# Altium schematic sheet 03 - Power.SchDoc
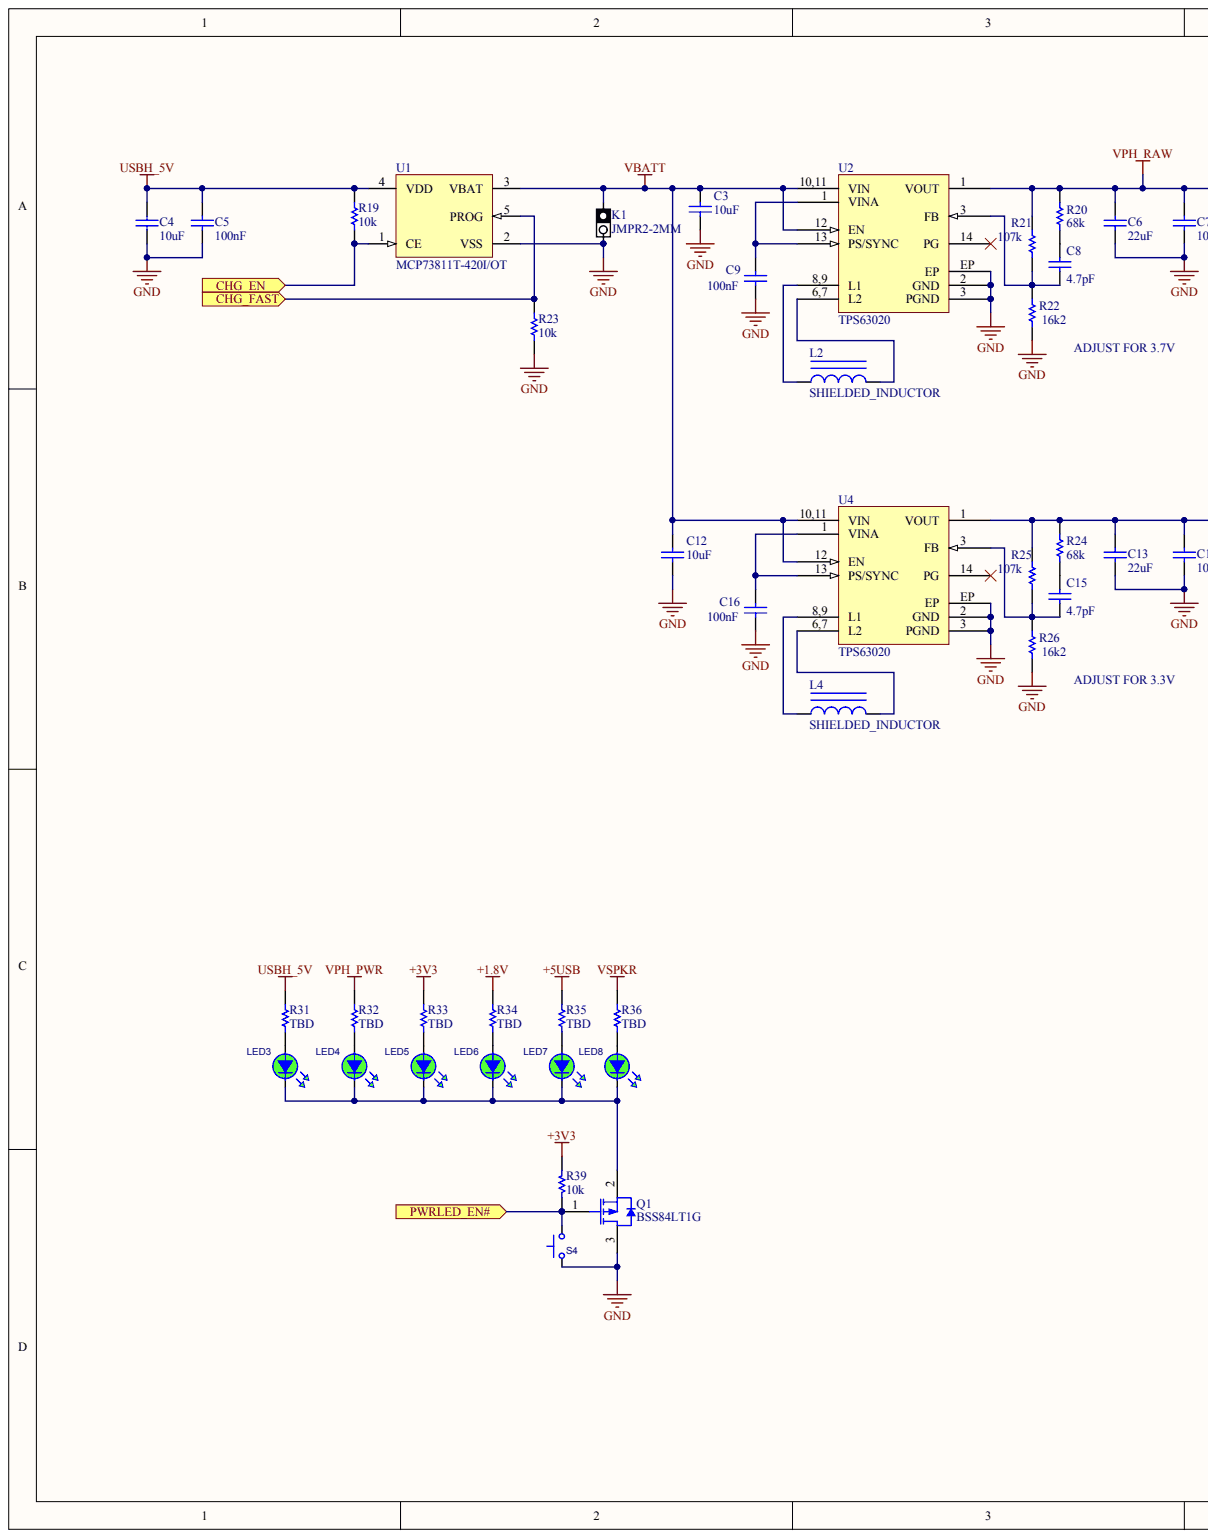
[diagram p0: 03 - Power.SchDoc, left]
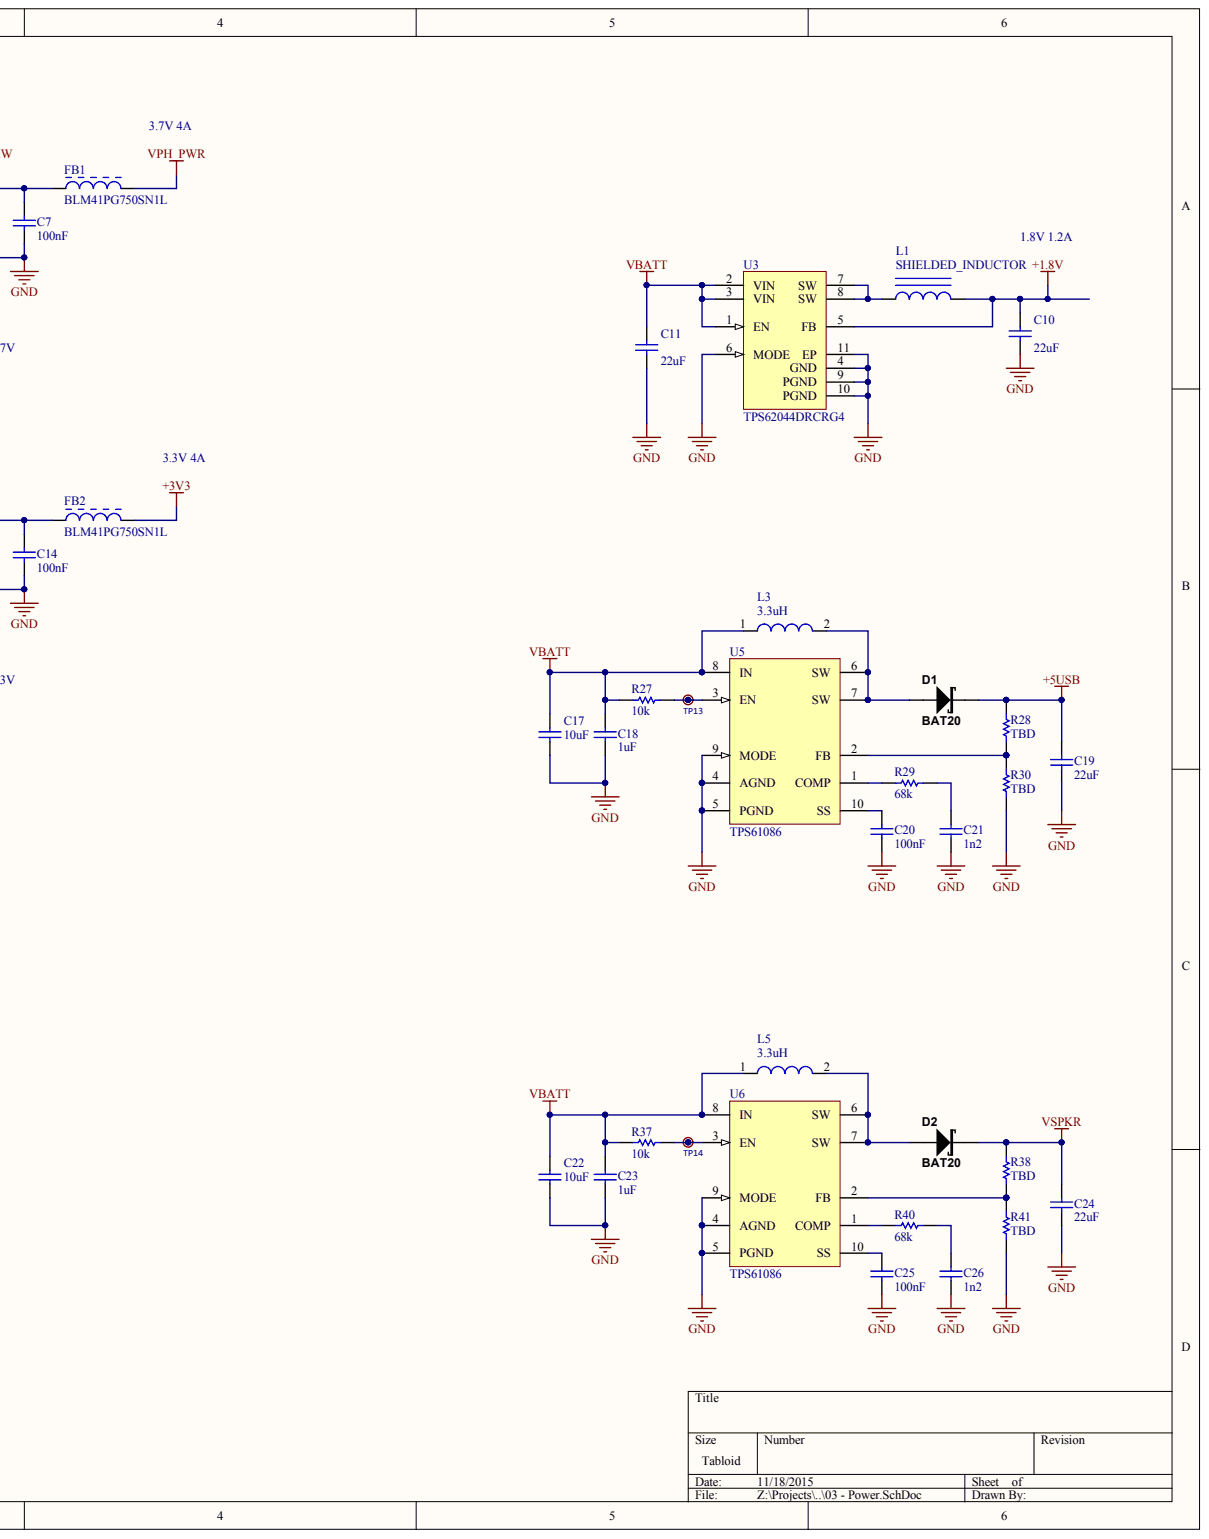
[diagram p1: 03 - Power.SchDoc, right]

## Parts
C3  value 10uF  [CAPC0805(2012)100_N]  (hidden comment: CAP 10uF 6.3V 0805(2012))
C4  value 10uF  [CAPC0805(2012)100_L]  (hidden comment: CAP 10uF 6.3V 0805(2012))
C5  value 100nF  [CAPC0805(2012)100_N]  (hidden comment: CAP 100nF 6.3V 0805(2012))
C6  value 22uF  [CAPC0805(2012)145_L]  (hidden comment: CAP 22uF 16V 0805(2012))
C7  value 100nF  [CAPC0805(2012)100_N]  (hidden comment: CAP 100nF 25V 0805(2012))
C8  value 4.7pF  [CAPC0805(2012)100_L]  (hidden comment: CAP 4.7pF 10V 0805(2012))
C9  value 100nF  [CAPC0805(2012)100_N]  (hidden comment: CAP 100nF 6.3V 0805(2012))
C10  value 22uF  [CAPC0805(2012)100_M]  (hidden comment: CAP 22uF 4V 0805(2012))
C11  value 22uF  [CAPC0805(2012)100_M]  (hidden comment: CAP 22uF 4V 0805(2012))
C12  value 10uF  [CAPC0805(2012)100_N]  (hidden comment: CAP 10uF 6.3V 0805(2012))
C13  value 22uF  [CAPC0805(2012)145_L]  (hidden comment: CAP 22uF 16V 0805(2012))
C14  value 100nF  [CAPC0805(2012)100_N]  (hidden comment: CAP 100nF 25V 0805(2012))
C15  value 4.7pF  [CAPC0805(2012)100_L]  (hidden comment: CAP 4.7pF 10V 0805(2012))
C16  value 100nF  [CAPC0805(2012)100_N]  (hidden comment: CAP 100nF 6.3V 0805(2012))
C17  value 10uF  [CAPC0805(2012)100_L]  (hidden comment: CAP 10uF 6.3V 0805(2012))
C18  value 1uF  [CAPC0805(2012)100_L]
C19  value 22uF  [CAPC0805(2012)145_L]  (hidden comment: CAP 22uF 16V 0805(2012))
C20  value 100nF  [CAPC0805(2012)100_N]  (hidden comment: CAP 100nF 6.3V 0805(2012))
C21  value 1n2  [CAPC0805(2012)100_N]
C22  value 10uF  [CAPC0805(2012)100_L]  (hidden comment: CAP 10uF 6.3V 0805(2012))
C23  value 1uF  [CAPC0805(2012)100_L]
C24  value 22uF  [CAPC0805(2012)145_L]  (hidden comment: CAP 22uF 16V 0805(2012))
C25  value 100nF  [CAPC0805(2012)100_N]  (hidden comment: CAP 100nF 6.3V 0805(2012))
C26  value 1n2  [CAPC0805(2012)100_N]
D1  BAT20  [SOD323]
D2  BAT20  [SOD323]
FB1  BLM41PG750SN1L  [MURA-BLM41-B35-CHIP-2_V2]
FB2  BLM41PG750SN1L  [MURA-BLM41-B35-CHIP-2_V2]
K1  JMPR2-2MM  [JMPR2-2MM]
L1  SHIELDED_INDUCTOR
L2  SHIELDED_INDUCTOR
L3  3.3uH  [SMD 0805_A]
L4  SHIELDED_INDUCTOR
L5  3.3uH  [SMD 0805_A]
LED3  VLMG1500-GS08  [LEDC0402(1005)_N-GRN]  (value not drawn)
LED4  VLMG1500-GS08  [LEDC0402(1005)_N-GRN]  (value not drawn)
LED5  VLMG1500-GS08  [LEDC0402(1005)_N-GRN]  (value not drawn)
LED6  VLMG1500-GS08  [LEDC0402(1005)_N-GRN]  (value not drawn)
LED7  VLMG1500-GS08  [LEDC0402(1005)_N-GRN]  (value not drawn)
LED8  VLMG1500-GS08  [LEDC0402(1005)_N-GRN]  (value not drawn)
Q1  BSS84LT1G  [ONSC-SOT-23-3-318-08_V]
R19  value 10k  [RESC0805(2012)_M]  (hidden comment: 10K 1% 0805(2012))
R20  value 68k  [RESC0805(2012)_L]  (hidden comment: 68K 5% 0805(2012))
R21  value 107k  [RESC0805(2012)_M]  (hidden comment: 107K 1% 0805(2012))
R22  value 16k2  [RESC0805(2012)_L]  (hidden comment: 16K2 1% 0805(2012))
R23  value 10k  [RESC0805(2012)_M]  (hidden comment: 10K 1% 0805(2012))
R24  value 68k  [RESC0805(2012)_L]  (hidden comment: 68K 5% 0805(2012))
R25  value 107k  [RESC0805(2012)_M]  (hidden comment: 107K 1% 0805(2012))
R26  value 16k2  [RESC0805(2012)_L]  (hidden comment: 16K2 1% 0805(2012))
R27  value 10k  [RESC0805(2012)_N]  (hidden comment: 20K 1% 0805(2012))
R28  value TBD  [RESC0805(2012)_N]  (hidden comment: 20K 1% 0805(2012))
R29  68k  [RESC0805(2012)_N]
R30  value TBD  [RESC0805(2012)_N]  (hidden comment: 20K 1% 0805(2012))
R31  value TBD  [RESC0805(2012)_M]
R32  value TBD  [RESC0805(2012)_M]
R33  value TBD  [RESC0805(2012)_M]
R34  value TBD  [RESC0805(2012)_M]
R35  value TBD  [RESC0805(2012)_M]
R36  value TBD  [RESC0805(2012)_M]
R37  value 10k  [RESC0805(2012)_N]  (hidden comment: 20K 1% 0805(2012))
R38  value TBD  [RESC0805(2012)_N]  (hidden comment: 20K 1% 0805(2012))
R39  value 10k  [RESC0805(2012)_M]  (hidden comment: 10K 1% 0805(2012))
R40  68k  [RESC0805(2012)_N]
R41  value TBD  [RESC0805(2012)_N]  (hidden comment: 20K 1% 0805(2012))
S4  KMR211GLFS  [KMR2]  (value not drawn)
TP13  (no value)  [TESTPOINT_035HOLE]
TP14  (no value)  [TESTPOINT_035HOLE]
U1  MCP73811T-420I/OT  [SOT-23-OT5_M]
U2  TPS63020
U3  TPS62044DRCRG4  [DRC10-2400X1650TP]
U4  TPS63020
U5  TPS61086  [SON50P300X300X100-11T175X250N]
U6  TPS61086  [SON50P300X300X100-11T175X250N]

## Ports
CHG_EN, CHG_FAST, PWRLED_EN#

## Nets
4: U5.11 U6.11
+1.8V: C10.2 L1.2 R34.1 U3.5(FB)
+3V3: FB2.2 R33.1 R39.1
+5USB: C19.2 D1.K R28.1 R35.1
GND: C3.1 C4.1 C5.2 C6.1 C7.1 C9.2 C10.1 C11.1 C12.1 C13.1 C14.1 C16.2 C17.1 C18.2 C19.1 C20.1 C21.1 C22.1 C23.2 C24.1 C25.1 C26.1 K1.2 Q1.3 R22.1 R23.1 R26.1 R30.2 R41.2 S4.1 U1.2(VSS) U2.2(GND) U2.3 U2.EP U3.4(GND) U3.6(MODE) U3.9(PGND) U3.10(PGND) U3.11(EP) U4.2(GND) U4.3 U4.EP U5.4(AGND) U5.5(PGND) U5.9(MODE) U6.4(AGND) U6.5(PGND) U6.9(MODE)
USBH_5V: C4.2 C5.1 R19.1 R31.1 U1.4(VDD)
VBATT: C3.2 C11.2 C12.2 C17.2 C18.1 C22.2 C23.1 K1.1 L3.1 L5.1 R27.2 R37.2 U1.3(VBAT) U2.10,11(VIN) U2.12(EN) U3.1(EN) U3.2(VIN) U3.3(VIN) U4.10,11(VIN) U4.12(EN) U5.8(IN) U6.8(IN)
VPH_PWR: FB1.2 R32.1
VPH_RAW: C6.2 C7.2 FB1.1 R20.2 R21.2 U2.1
VSPKR: C24.2 D2.K R36.1 R38.1
CHG_EN: R19.2 U1.1(CE) ; port CHG_EN
CHG_FAST: R23.2 U1.5(PROG) ; port CHG_FAST
PWRLED_EN#: Q1.1 R39.2 S4.3 ; port PWRLED_EN#
NetC8_1: C8.1 R21.1 R22.2 U2.3
NetC8_2: C8.2 R20.1
NetC9_1: C9.1 U2.1 U2.13(PS/SYNC)
NetC13_2: C13.2 C14.2 FB2.1 R24.2 R25.2 U4.1
NetC15_1: C15.1 R25.1 R26.2 U4.3
NetC15_2: C15.2 R24.1
NetC16_1: C16.1 U4.1 U4.13(PS/SYNC)
NetC20_2: C20.2 U5.10(SS)
NetC21_2: C21.2 R29.1
NetC25_2: C25.2 U6.10(SS)
NetC26_2: C26.2 R40.1
NetD1_A: D1.A L3.2 U5.6(SW) U5.7(SW)
NetD2_A: D2.A L5.2 U6.6(SW) U6.7(SW)
NetL1_1: L1.1 U3.7(SW) U3.8(SW)
NetL2_1: L2.1 U2.8,9(L1)
NetL2_2: L2.2 U2.6,7(L2)
NetL4_1: L4.1 U4.8,9(L1)
NetL4_2: L4.2 U4.6,7(L2)
NetLED3_A: LED3.A R31.2
NetLED3_K: LED3.K LED4.K LED5.K LED6.K LED7.K LED8.K Q1.2
NetLED4_A: LED4.A R32.2
NetLED5_A: LED5.A R33.2
NetLED6_A: LED6.A R34.2
NetLED7_A: LED7.A R35.2
NetLED8_A: LED8.A R36.2
NetR27_1: R27.1 TP13.1 U5.3(EN)
NetR28_2: R28.2 R30.1 U5.2(FB)
NetR29_2: R29.2 U5.1(COMP)
NetR37_1: R37.1 TP14.1 U6.3(EN)
NetR38_2: R38.2 R41.1 U6.2(FB)
NetR40_2: R40.2 U6.1(COMP)

Not connected: U2 14(PG); U4 14(PG)

## Library data of the parts
C3 C12 = CMP-1036-04920-1: CAP 10uF 6.3V Â±20% 0805 (2012 Metric) Thickness 1mm SMD; Case-EIA: 0805; Case-Metric: 2012; Component Kind: Standard; Library Name: Altium Inc., Content Vault; Max Thickness: 1 mm; Rated Voltage: 6.3 V; Technology: SMT; Tolerance: Â±20%
C4 C17 C22 = CMP-1036-04917-1: CAP 10uF 6.3V Â±10% 0805 (2012 Metric) Thickness 1mm SMD; Case-EIA: 0805; Case-Metric: 2012; Component Kind: Standard; Library Name: Altium Content Vault; Max Thickness: 1 mm; Rated Voltage: 6.3 V; Technology: SMT; Tolerance: Â±10%
C5 C9 C16 C20 C25 = CMP-1036-04391-1: CAP 100nF 6.3V Â±10% 0805 (2012 Metric) Thickness 1mm SMD; Case-EIA: 0805; Case-Metric: 2012; Component Kind: Standard; Library Name: Altium Content Vault; Max Thickness: 1 mm; Rated Voltage: 6.3 V; Technology: SMT; Tolerance: Â±10%
C6 C13 C19 C24 = CMP-1036-04946-1: CAP 22uF 16V Â±20% 0805 (2012 Metric) Thickness 1.45mm SMD; Case-EIA: 0805; Case-Metric: 2012; Component Kind: Standard; Library Name: Altium Content Vault; Max Thickness: 1.45 mm; Rated Voltage: 16 V; Technology: SMT; Tolerance: Â±20%
C7 C14 = CMP-1036-04411-1: CAP 100nF 25V Â±10% 0805 (2012 Metric) Thickness 1mm SMD; Case-EIA: 0805; Case-Metric: 2012; Component Kind: Standard; Library Name: Altium Content Vault; Max Thickness: 1 mm; Rated Voltage: 25 V; Technology: SMT; Tolerance: Â±10%
C8 C15 = CMP-1036-00526-1: CAP 4.7pF 10V Â±0.25pF 0805 (2012 Metric) Thickness 1mm SMD; Case-EIA: 0805; Case-Metric: 2012; Component Kind: Standard; Library Name: Altium Inc., Content Vault; Max Thickness: 1 mm; Rated Voltage: 10 V; Technology: SMT; Tolerance: Â±0.25pF
C10 C11 = CMP-1036-04937-1: CAP 22uF 4V Â±20% 0805 (2012 Metric) Thickness 1mm SMD; Case-EIA: 0805; Case-Metric: 2012; Component Kind: Standard; Library Name: Altium Inc., Content Vault; Max Thickness: 1 mm; Rated Voltage: 4 V; Technology: SMT; Tolerance: Â±20%
C18 C23 = CMP-1036-04917-1: Case-EIA: 0805; Case-Metric: 2012; Rated Voltage: 6.3 V; Tolerance: Â±10%; Component Kind: Standard; Library Name: Altium Content Vault; Max Thickness: 1 mm; Technology: SMT
C21 C26 = CMP-1036-04391-1: Case-EIA: 0805; Case-Metric: 2012; Rated Voltage: 6.3 V; Tolerance: Â±10%; Component Kind: Standard; Library Name: Altium Content Vault; Max Thickness: 1 mm; Technology: SMT
D1 D2 = BAT20-SOD323: Schottky Diode SOD323 1A 23V; Component Kind: Standard; Library Name: vh_altium_library.intLib; Supplier 1: Digi-Key; Supplier Part Number 1: 497-3381-2-ND
FB1 FB2 = CMP-0686-00749-2: Chip Ferrite Bead for Power Lines, 75 Ohm, 3500 mA, -55 to 125 degC, 4.5 x 1.6 x 1.8 mm SMD, Tape and Reel; Manufacturer: Murata; DC Resistance: 0.015 Ohm Max.; Impedance (at 100 MHz): 75 Ohm Typ.; Rated Current: 3500 mA; Component Kind: Standard; ComponentLink1Description: Manufacturer URL; ComponentLink1URL: http://www.murata.com/; ComponentLink2Description: Datasheet; ComponentLink2URL: http://www.murata.com/products/catalog/pdf/c31e.pdf; ComponentLink3Description: Package Specification; ComponentLink3URL: http://www.murata.com/products/catalog/pdf/c31e.pdf; DatasheetVersion: 08/2013; Library Name: Altium Content Vault; Mounting Technology: Surface Mount; Operating Temperature Range: -55 to 125 degC; PackageDescription: 2-Pin Chip, Body 4.5 x 1.6 mm; PackageReference: BLM41-2; Packing: Tape and Reel; PartNumber: BLM41PG750SN1L
K1 = JMPR2-2MM: JMPR2 2MM OPEN BY DEFAULT; Manufacturer: Sullins Connector Solutions; Manufacturer Part Number: PBC36SAAN; Component Kind: Standard; Library Name: vh_altium_library.intLib; Reel: Open; Supplier 1: Digi-Key; Supplier Part Number 1: S1011E-36-ND
L3 L5 = CMP-1392-00033-1: Power Multilayer Inductor WE-PMI, L=1.00 ÂµH; Manufacturer: Wuerth Elektronik; Component Kind: Standard; ComponentLink1Description: Manufacturer URL; ComponentLink1URL: http://www.we-online.com; ComponentLink2Description: Datasheet; ComponentLink2URL: http://katalog.we-online.de/kataloge/eisos/media/pdf/74479775210A.pdf; Library Name: Altium Content Vault; PackageReference: SMD 0805_A
LED3-LED8 = LED_0402_VLMG1500-GS08: LED GREEN 0402 SMD; Manufacturer: Vishay Semiconductor Opto Division; Current - Test: 20mA; Lens Style/Size: Rectangle with Flat Top, 1.00mm x 0.50mm; Millicandela Rating: 35mcd; Viewing Angle: 130°; Voltage - Forward (Vf) (Typ): 2V; Wavelength - Dominant: 571nm; Wavelength - Peak: 574nm; Category: Optoelectronics; Color: Green; Component Kind: Standard; ComponentLink1Description: http://www.vishay.com/docs/82554/vlmo1500.pdf; ComponentLink1URL: http://www.vishay.com/docs/82554/vlmo1500.pdf; Height (Max): 0.35mm; Lens Color: -; Lens Transparency: -; Library Name: RevAir32.IntLib; Manufacturer Part Number: VLMG1500-GS08; Mounting Type: Surface Mount; Package / Case: 0402 (1005 Metric); Packaging: Cut Tape (CT); Pricing 1: 1=0.52, 10=0.384, 25=0.3072, 100=0.2035, 250=0.144, 500=0.12288, 750=0.10368, 1000=0.096 (USD); RoHS: RoHS Compliant; Size / Dimension: 1.00mm L x 0.50mm W; Stock 1: 37065; Supplier 1: Digi-Key; Supplier Device Package: 0402; Supplier Part Number 1: VLMG1500-GS08CT-ND
Q1 = CMP-1058-00762-1: Power MOSFET Single P-Channel, -50 V, 10 Ohm, 3-Pin SOT-23, Pb-Free, Tape and Reel; Manufacturer: On Semiconductor; Channel Polarity: P Channel; Ciss Typ (pF): 36; Coss Typ (pF): 17; Crss Typ (pF): 6.5; ID Max (A): 0.13; PD Max (W): 0.225; V(BR)DSS Min (V): 50; VGS(th) Max (V): 2; VGS Max (V): 20; Component Kind: Standard; ComponentLink1Description: Manufacturer URL; ComponentLink1URL: http://www.onsemi.com/; ComponentLink2Description: Datasheet; ComponentLink2URL: http://www.onsemi.com/pub_link/Collateral/BSS84LT1-D.PDF; ComponentLink3Description: Package Specification; ComponentLink3URL: http://www.onsemi.cn/pub_link/Collateral/318-08.PDF; Configuration: Single; DatasheetVersion: Rev. 8; Library Name: Altium Inc., Content Vault; Mounting Technology: Surface Mount; PackageDescription: 3-Pin Small Outline Transistor, Body 2.92 x 1.3 mm, Pitch 0.95 mm; PackageReference: SOT-23-3-318-08; Package Type: SOT-23-3; PackageVersion: Rev. AP, 11/2009; Packing: Tape and Reel; PartNumber: BSS84LT1G; RoHS: Pb-Free
R19 R23 R31-R36 R39 = CMP-1013-00623-1: 10K 0.125W 1% 0805 (2012 Metric)  SMD; Case-EIA: 0805; Case-Metric: 2012; Component Kind: Standard; Power: 0.125 W; Technology: SMT; Tolerance: 1 %
  R19 R23 R31-R36: Library Name: Altium Inc., Content Vault
  R39: Library Name: Altium Content Vault
R20 R24 = CMP-1013-00118-1: 68K 0.125W 5% 0805 (2012 Metric)  SMD; Case-EIA: 0805; Case-Metric: 2012; Component Kind: Standard; Library Name: Altium Inc., Content Vault; Power: 0.125 W; Technology: SMT; Tolerance: 5 %
R21 R25 = CMP-1013-00739-1: 107K 0.125W 1% 0805 (2012 Metric)  SMD; Case-EIA: 0805; Case-Metric: 2012; Component Kind: Standard; Library Name: Altium Inc., Content Vault; Power: 0.125 W; Technology: SMT; Tolerance: 1 %
R22 R26 = CMP-1013-00645-1: 16K2 0.125W 1% 0805 (2012 Metric)  SMD; Case-EIA: 0805; Case-Metric: 2012; Component Kind: Standard; Library Name: Altium Inc., Content Vault; Power: 0.125 W; Technology: SMT; Tolerance: 1 %
R27 R28 R30 R37 R38 R41 = CMP-1013-00655-1: 20K 0.125W 1% 0805 (2012 Metric)  SMD; Case-EIA: 0805; Case-Metric: 2012; Component Kind: Standard; Library Name: Altium Content Vault; Power: 0.125 W; Technology: SMT; Tolerance: 1 %
R29 R40 = CMP-1013-00655-1: Case-EIA: 0805; Case-Metric: 2012; Power: 0.125 W; Tolerance: 1 %; Component Kind: Standard; Library Name: Altium Content Vault; Technology: SMT; Value: 68k
S4 = SW_KMR211G LFS: SWITCH TACTILE SPST-NO 0.05A 32V; Manufacturer: C&K Components; Actuator Height off PCB, from Pins: 1.90mm; Actuator Orientation: Top Actuated; Actuator Type: Standard; Contact Rating @ Voltage: 0.05A @ 32VDC; Illumination: Non-Illuminated; Mechanical Life: 200,000 Cycles; Operating Force: 120gf; Outline: 4.60mm x 2.80mm; Switch Function: Off-Mom; Switch Travel: 0.20mm; Actuator Material: -; Category: Switches; Circuit: SPST-NO; Component Kind: Standard; ComponentLink1Description: http://www.ck-components.com/index.php?module=media&action=Display&cmpref=14414&lang=en&width=&height=&format=&alt=; ComponentLink1URL: http://www.ck-components.com/index.php?module=media&action=Display&cmpref=14414&lang=en&width=&height=&format=&alt=; Features: Grounding Pin; Illumination Type, Color: -; Illumination Voltage (Nominal): -; Ingress Protection: -; Library Name: RevAir32.IntLib; Manufacturer Part Number: KMR211GLFS; Mounting Type: Surface Mount; Operating Temperature: -40°C ~ 85°C; Packaging: Cut Tape (CT); Pricing 1: 1=0.51, 10=0.493, 25=0.484, 50=0.4656, 100=0.4017, 250=0.3652, 500=0.34694, 1000=0.2739 (USD); RoHS: RoHS Compliant; Stock 1: 4378; Supplier 1: Digi-Key; Supplier Part Number 1: 401-1426-1-ND; Termination Style: Gull Wing
TP13 TP14 = TESTPOINTHOLE035: Component Kind: Standard; Library Name: ak-misc.SCHLIB
U1 = CMP-0133-00139-1: Simple, Miniature Single-Cell, Fully Integrated Li-Ion/Li-Polymer Charge Management Controller, 4.2V, 5-Pin SOT-23, Industrial Temperature, Tape and Reel; Code_IPC: SOT23-5; Component Kind: Standard; DatasheetVersion: revB, Sep-2007; Library Name: Altium Inc., Content Vault; PackageDescription: 5-Lead Plastic Small Outline Transistor (OT) [SOT-23]; PackageReference: SOT-23-OT5; PackageVersion: revBB, Aug-2009
U3 = CMP-0323-00557-1: Buck Step Down Regulator with 2.5 to 6 V Input and 1.8 V Output, -40 to 85 degC, 10-Pin SON (DRC), Green (RoHS & no Sb/Br); Manufacturer: Texas Instruments; Duty Cycle(Max)(%): 100; Iout(Max)(A): 1.2; Iq(Typ)(mA): 0.018; Preset Vout(V): 1.8; Rating: Catalog; Regulated Outputs(#): 1; SubFamily: Step-Down Regulator; Switch Current Limit(Typ)(A): 2; Vin(Max)(V): 6; Vin(Min)(V): 2.5; Component Kind: Standard; ComponentLink1Description: Datasheet; ComponentLink1URL: http://www.ti.com/general/docs/lit/getliterature.tsp?genericPartNumber=TPS62044&fileType=pdf; ComponentLink2Description: Package Specification; ComponentLink2URL: http://www.ti.com/litv/pdf/mpds117k; ComponentLink3Description: URL Link1; ComponentLink3URL: Samples URL:http://www.ti.com/product/tps54611#samples; DatasheetVersion: SLVS463B; Eco-Plan: Green (RoHS & no Sb/Br); Library Name: Altium Inc., Content Vault; Mounting Technology: Surface Mount; PackageDescription: 10-Pin Plastic Small Outline No-Lead, 3 x 3 x 1 mm Body, 0.5 mm Pitch, Exposed Pad; PackageReference: DRC10-2400X1650TP; PackageVersion: revL, Sep-2011; PartNumber: TPS62044DRCRG4; Topology: Buck; Vout(Max)(V): 1.8; Vout(Min)(V): 1.8
L1 L2 L4 = SHIELDED_INDUCTOR: Component Kind: Standard; Library Name: traxx.SchLib
U2 U4 = TPS63020: Component Kind: Standard; Library Name: traxx.SchLib
U5 U6 = TPS61086: Component Kind: Standard; Library Name: tps61086.SchLib
